# Altium schematic sheet connectors.SchDoc(connectors)
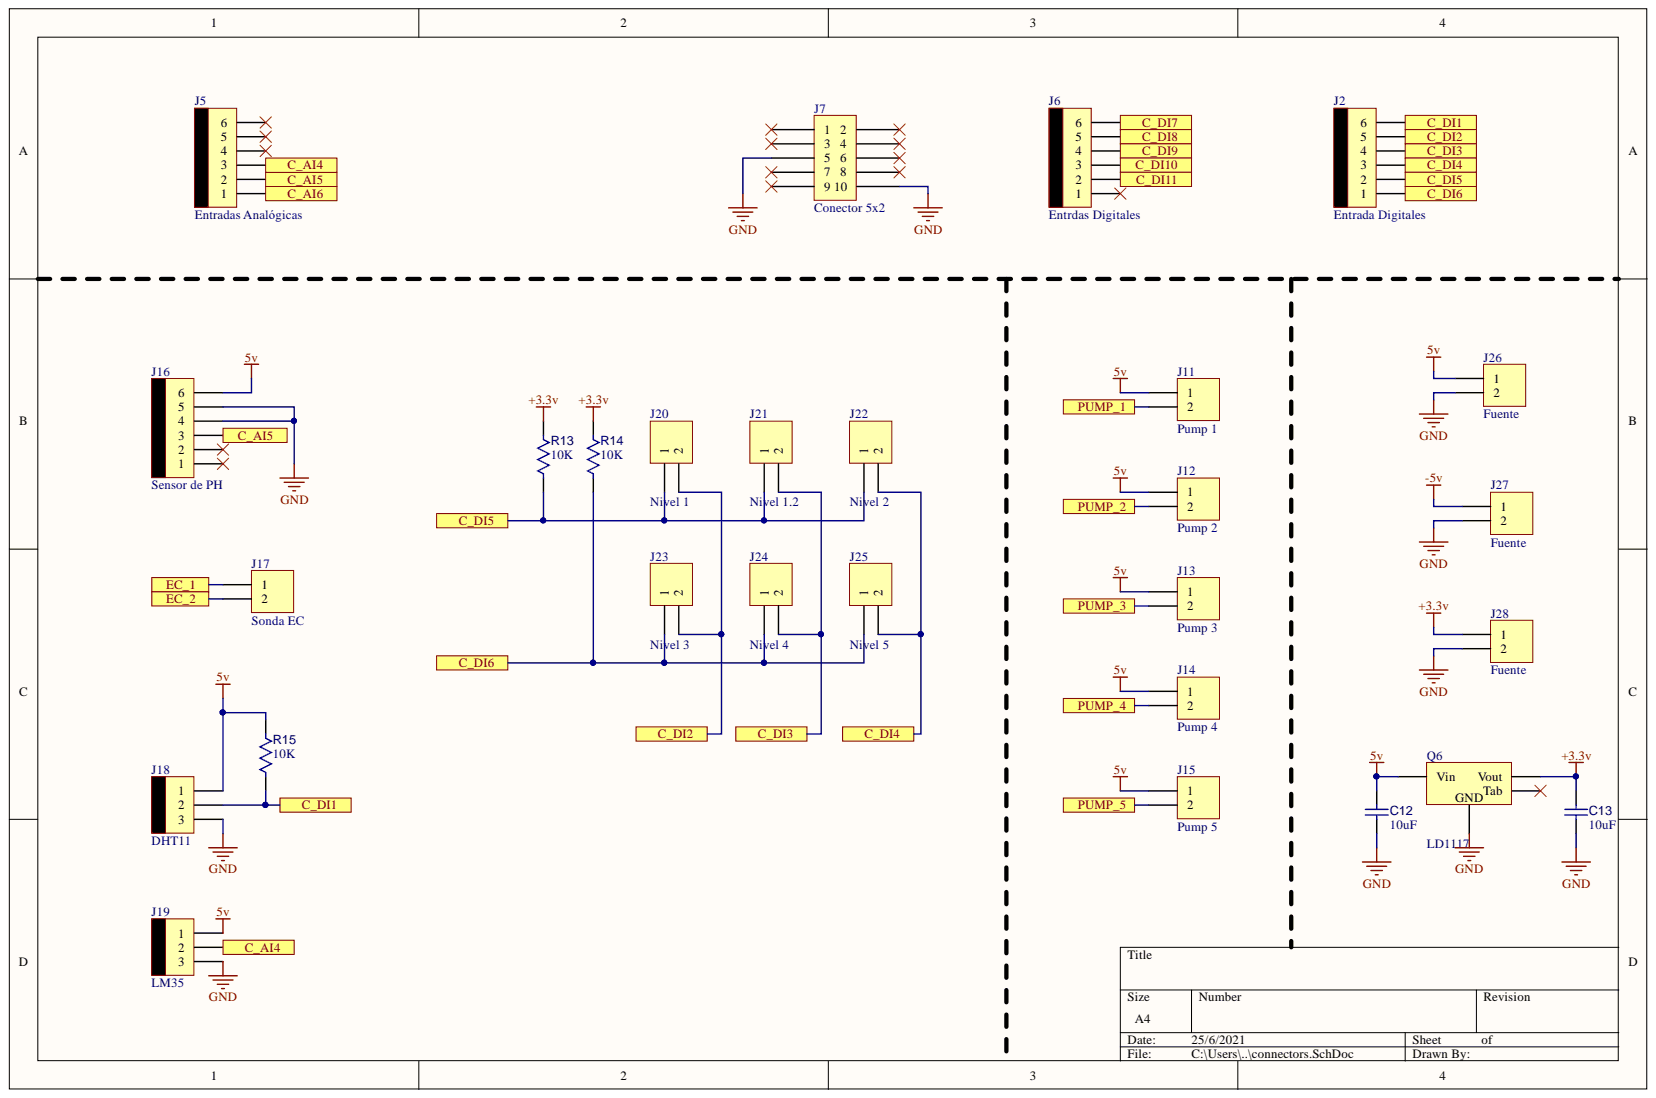
[diagram p0: connectors.SchDoc]

## Parts
C12  10uF  [CAPC3216x130N]
C13  10uF  [CAPC3216x130N]
J2  Entrada Digitales  [MOLEX6]
J5  Entradas Analógicas  [MOLEX6]
J6  Entrdas Digitales  [MOLEX6]
J7  Conector 5x2  [CONN_5x2_BOX]
J11  Pump 1  [CON_2_156]
J12  Pump 2  [CON_2_156]
J13  Pump 3  [CON_2_156]
J14  Pump 4  [CON_2_156]
J15  Pump 5  [CON_2_156]
J16  Sensor de PH  [MOLEX6]
J17  Sonda EC  [HDR1X2H]
J18  DHT11  [MOLEX3]
J19  LM35  [MOLEX3]
J20  Nivel 1  [CON_2_156]
J21  Nivel 1.2  [CON_2_156]
J22  Nivel 2  [CON_2_156]
J23  Nivel 3  [CON_2_156]
J24  Nivel 4  [CON_2_156]
J25  Nivel 5  [CON_2_156]
J26  Fuente  [CON_2_156]
J27  Fuente  [CON_2_156]
J28  Fuente  [CON_2_156]
Q6  LD1117  [SOT223_N]
R13  10K  [0805]
R14  10K  [0805]
R15  10K  [0805]

## Ports
C_AI4, C_AI5, C_AI6, C_DI1, C_DI2, C_DI3, C_DI4, C_DI5, C_DI6, C_DI7, C_DI8, C_DI9, C_DI10, C_DI11, EC_1, EC_2, PUMP_1, PUMP_2, PUMP_3, PUMP_4, PUMP_5

## Nets
5v: C12.1 J11.1 J12.1 J13.1 J14.1 J15.1 J16.6 J18.1 J19.1 J26.1 Q6.3(Vin) R15.2
+3.3v: C13.2 J28.1 Q6.2(Vout) R13.2 R14.2
-5v: J27.1
GND: C12.2 C13.1 J7.5 J7.10 J16.4 J16.5 J18.3 J19.3 J26.2 J27.2 J28.2 Q6.1
C_AI4: J5.3 J19.2 ; port C_AI4
C_AI5: J5.2 J16.3 ; port C_AI5
C_AI6: J5.1 ; port C_AI6
C_DI1: J2.6 J18.2 R15.1 ; port C_DI1
C_DI2: J2.5 J20.2 J23.2 ; port C_DI2
C_DI3: J2.4 J21.2 J24.2 ; port C_DI3
C_DI4: J2.3 J22.2 J25.2 ; port C_DI4
C_DI5: J2.2 J20.1 J21.1 J22.1 R13.1 ; port C_DI5
C_DI6: J2.1 J23.1 J24.1 J25.1 R14.1 ; port C_DI6
C_DI7: J6.6 ; port C_DI7
C_DI8: J6.5 ; port C_DI8
C_DI9: J6.4 ; port C_DI9
C_DI10: J6.3 ; port C_DI10
C_DI11: J6.2 ; port C_DI11
EC_1: J17.1 ; port EC_1
EC_2: J17.2 ; port EC_2
PUMP_1: J11.2 ; port PUMP_1
PUMP_2: J12.2 ; port PUMP_2
PUMP_3: J13.2 ; port PUMP_3
PUMP_4: J14.2 ; port PUMP_4
PUMP_5: J15.2 ; port PUMP_5

Not connected: J5 4 5 6; J6 1; J7 1 2 3 4 6 7 8 9; J16 1 2; Q6 4(Tab)

## Library data of the parts
C12 C13 = Cap: Voltage-Rated: 6.3V; Tolerance: ±10%; Value: 10uF; Package: 0603; Library Name: mylib.SchLib; Description: Cap; Component Kind: Standard
J2 J5 J6 J16 = MOLEX6: Library Name: LIBEBAL_1108190909.IntLib; Component Kind: Standard
J11-J15 J20-J28 = Header 2: Header, 2-Pin; Signal Integrity: Connector; Library Name: mylib.SchLib; LatestRevisionNote: Re-released for DXP Platform.; LatestRevisionDate: 17-Jul-2002; Component Kind: Standard; Publisher: Altium Limited
J17 = Header 2H: Header, 2-Pin, Right Angle; Signal Integrity: Connector; Library Name: Miscellaneous Connectors.IntLib; LatestRevisionNote: Re-released for DXP Platform.; LatestRevisionDate: 17-Jul-2002; Component Kind: Standard; Publisher: Altium Limited
J18 J19 = MOLEX3: Library Name: LIBEBAL_1108190909.IntLib; Component Kind: Standard
Q6 = LD1117: Voltage Reg., Low Drop; PackageReference: SOT-223; PackageDescription: 3-Pin Transistor Outline Package 2.54 mm Pitch, 15.1 mm Lead Span; Library Name: mylib.SchLib; Component Kind: Standard
R13-R15 = Res: Resistor; Tolerance: 1%; Value: 10K; Package: 0603; Library Name: mylib.SchLib; Component Kind: Standard
J7 = Conector 5x2: Library Name: mylib.SchLib; Component Kind: Standard
